# Altium schematic sheet dimmer.SchDoc
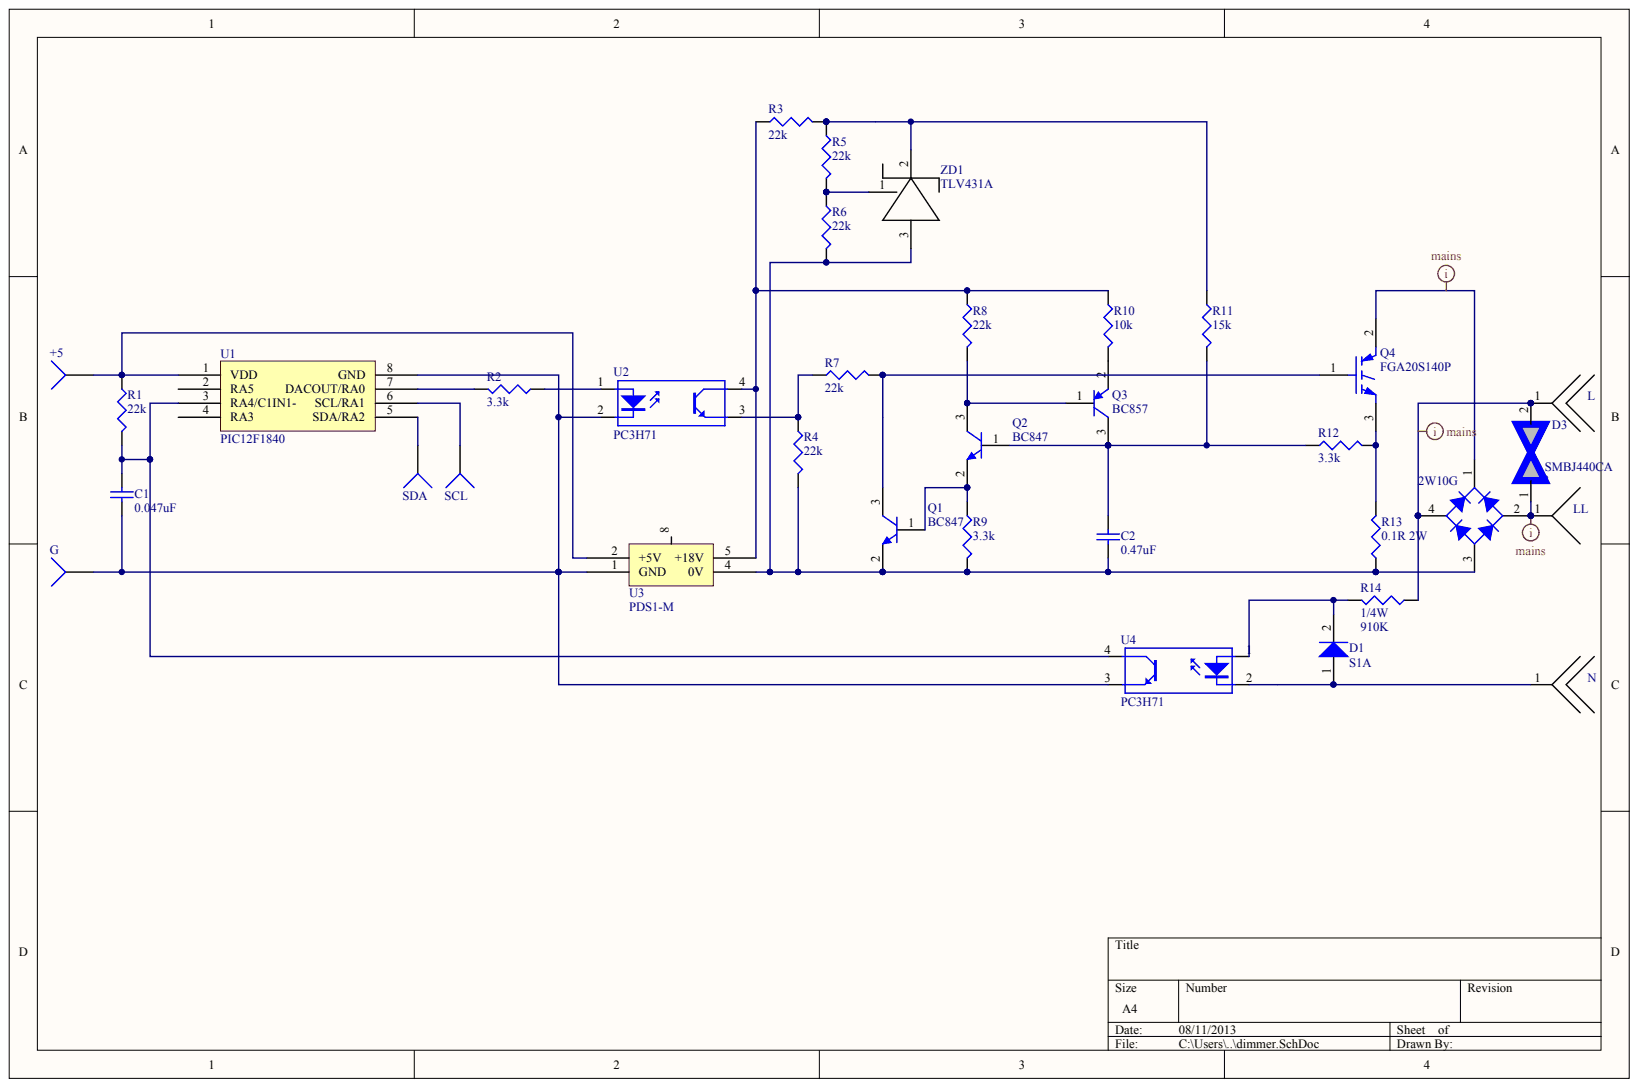
[diagram p0: dimmer.SchDoc]

## Parts
+5  Socket  (value not drawn)
C1  0.047uF
C2  0.47uF
D1  S1A
D2  2W10G
D3  SMBJ440CA
G  Socket  (value not drawn)
L  PWRCON2X  (value not drawn)
LL  PWRCON  (value not drawn)
N  PWRCON2X  (value not drawn)
Q1  BC847
Q2  BC847
Q3  BC857
Q4  FGA20S140P
R1  22k
R2  3.3k
R3  22k
R4  22k
R5  22k
R6  22k
R7  22k
R8  22k
R9  3.3k
R10  10k
R11  15k
R12  3.3k
R13  0.1R 2W
R14  1/4W  value 910K
SCL  Socket  (value not drawn)
SDA  Socket  (value not drawn)
U1  PIC12F1840
U2  PC3H71
U3  PDS1-M
U4  PC3H71
ZD1  TLV431A

## Nets
Net+5_1: +5.1 R1.1 U1.1(VDD) U3.2(+5V)
NetC1_1: C1.1 G.1 U1.8(GND) U2.2 U3.1(GND) U4.3
NetC1_2: C1.2 R1.2 U1.3(RA4/C1IN1-) U4.4
NetC2_1: C2.1 D2.3 Q1.2 R4.2 R6.2 R9.2 R13.1 U3.4(0V) ZD1.3
NetC2_2: C2.2 Q2.1 Q3.3 R11.2 R12.1
NetD1_1: D1.1 N.1 U4.2
NetD1_2: D1.2 R14.2 U4.1
NetD2_1: D2.1 Q4.2
NetD2_2: D2.2 D3.1 LL.1
NetD2_4: D2.4 D3.2 L.1 R14.1
NetQ1_1: Q1.1 Q2.2 R9.1
NetQ1_3: Q1.3 Q4.1 R7.2
NetQ2_3: Q2.3 Q3.1 R8.2
NetQ3_2: Q3.2 R10.2
NetQ4_3: Q4.3 R12.2 R13.2
NetR2_1: R2.1 U2.1
NetR2_2: R2.2 U1.7(DACOUT/RA0)
NetR3_1: R3.1 R8.1 R10.1 U2.4 U3.5(+18V)
NetR3_2: R3.2 R5.1 R11.1 ZD1.2
NetR4_1: R4.1 R7.1 U2.3
NetR5_2: R5.2 R6.1 ZD1.1
NetSCL_1: SCL.1 U1.6(SCL/RA1)
NetSDA_1: SDA.1 U1.5(SDA/RA2)

Not connected: U1 2(RA5) 4(RA3); U3 8

## Library data of the parts
R13 = (no symbol name): Value: 0.1; Published: 8-Jun-2000; LatestRevisionDate: 29-May-2009; LatestRevisionNote: IPC-7351 Footprint Added.; PackageReference: J1-0603; PackageDescription: Chip Resistor; Publisher: Altium Limited
R14 = (no symbol name): Value: 910K; Published: 8-Jun-2000; LatestRevisionDate: 29-May-2009; LatestRevisionNote: IPC-7351 Footprint Added.; PackageReference: J1-0603; PackageDescription: Chip Resistor; Publisher: Altium Limited
+5 C1 C2 D1-D3 G L LL N Q1-Q4 R1-R12 SCL SDA U1-U4 ZD1 = (no symbol name)
  +5 G SCL SDA: LatestRevisionDate: 17-Jul-2002; LatestRevisionNote: Re-released for DXP Platform.
  C1: Published: 8-Jun-2000; LatestRevisionDate: 29-May-2009; LatestRevisionNote: IPC-7351 Footprint Added.; PackageReference: C1206; PackageDescription: Chip Capacitor; Value: 0.047uF
  C2: Published: 8-Jun-2000; LatestRevisionDate: 29-May-2009; LatestRevisionNote: IPC-7351 Footprint Added.; PackageReference: C1206; PackageDescription: Chip Capacitor; Value: 0.47uF
  D1: Published: 8-Jun-2000; LatestRevisionDate: 17-Jul-2002; LatestRevisionNote: Re-released for DXP Platform.; PackageVersion: Sep-1996; PackageReference: SMC; PackageDescription: DO-214-AB/SMC; 2 C-Bend Leads; Body 7.9 x 5.9 mm, inc. leads (LxW); Code_JEDEC: DO-214-AB
  D2: Published: 8-Jun-2000; LatestRevisionDate: 29-May-2009; LatestRevisionNote: Sch pins A & K swapped.; DatasheetVersion: E2732B; PackageReference: D-38; PackageDescription: Bridge Rectifier; 4 Terminals; Body 9.4 x 9.4 mm (typ); Pitch 5.08 mm
  Q1 Q2: Published: 8-Jun-2000; LatestRevisionDate: 17-Jul-2002; LatestRevisionNote: Re-released for DXP Platform.; PackageReference: TO-226-AA; PackageDescription: TO, Flat Index; 3 In-Line, Axial Leads; Body Dia. 4.8mm; Leads 0.55 x 0.51 mm (max); Code_JEDEC: TO-226-AA
  Q3: Published: 8-Jun-2000; LatestRevisionDate: 29-May-2009; LatestRevisionNote: IPC-7351 Footprint Added.; PackageVersion: Aug-1999; PackageReference: SOT-23B; PackageDescription: 3-Pin SOT-23 Package 0.95 mm Pitch, 2.4 mm Lead Span
  Q4: Published: 8-Jun-2000; LatestRevisionDate: 17-Jul-2002; LatestRevisionNote: Re-released for DXP Platform.; DatasheetVersion: PD-9.684A; PackageReference: TO-247; PackageDescription: SIP with Mounting Hole; 3 Leads; Body 20 x 15.6 x 5 mm (typ); Pitch 5.45 mm; Code_JEDEC: TO-247-AC; ComponentLink1Description: Manufacturer Link; ComponentLink1URL: http://www.irf.com/
  R1 R3-R8: Published: 8-Jun-2000; LatestRevisionDate: 29-May-2009; LatestRevisionNote: IPC-7351 Footprint Added.; PackageReference: J1-0603; PackageDescription: Chip Resistor; Value: 22K
  R2 R9 R12: Published: 8-Jun-2000; LatestRevisionDate: 29-May-2009; LatestRevisionNote: IPC-7351 Footprint Added.; PackageReference: J1-0603; PackageDescription: Chip Resistor; Value: 3.3K
  R10: Published: 8-Jun-2000; LatestRevisionDate: 29-May-2009; LatestRevisionNote: IPC-7351 Footprint Added.; PackageReference: J1-0603; PackageDescription: Chip Resistor; Value: 10K
  R11: Published: 8-Jun-2000; LatestRevisionDate: 29-May-2009; LatestRevisionNote: IPC-7351 Footprint Added.; PackageReference: J1-0603; PackageDescription: Chip Resistor; Value: 15K
  U2 U4: Published: 24-Mar-1999; LatestRevisionDate: 04-Mar-2005; LatestRevisionNote: Sch pins 3 & 4 Swapped.; DatasheetVersion: Nov-2003; PackageReference: DIP-4
  +5 C1 C2 D1 D2 G Q1-Q4 R1-R12 SCL SDA U2 U4: Publisher: Altium Limited
